# Altium schematic sheet MAIN.SchDoc(MAIN)
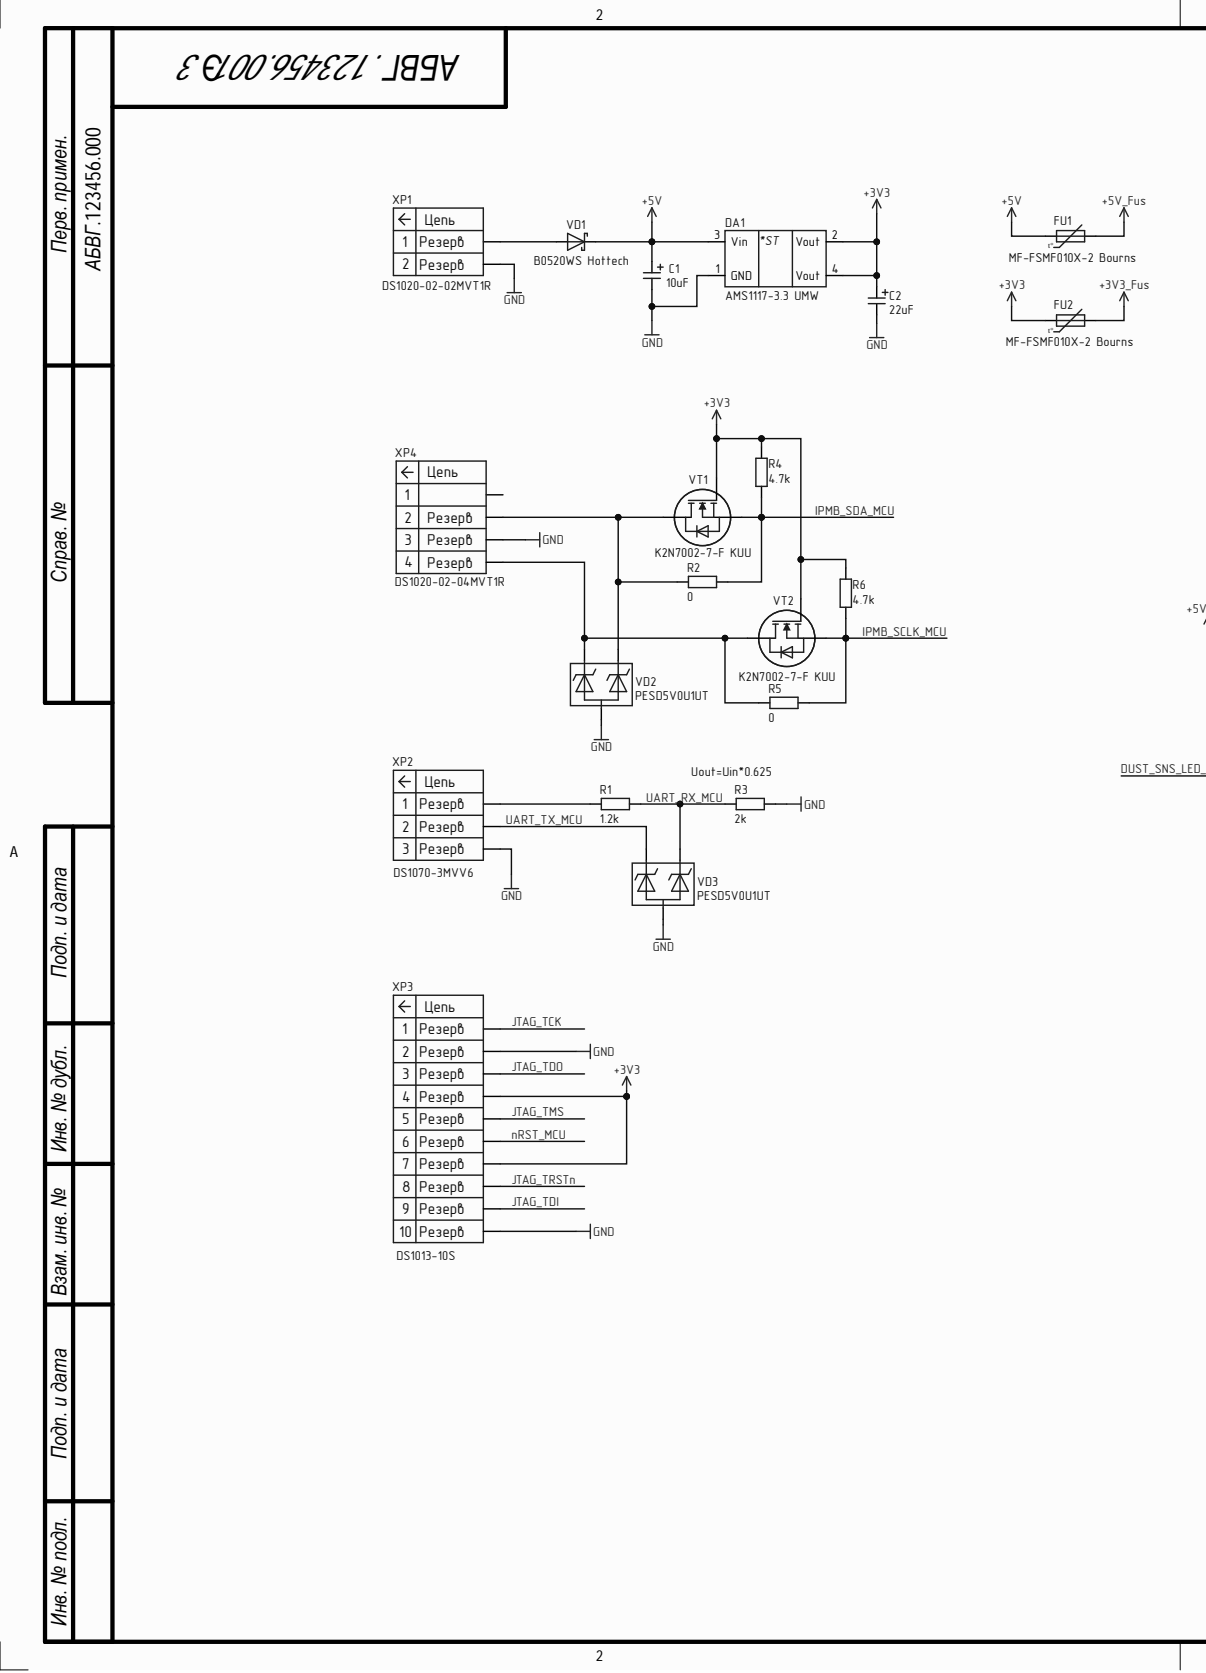
[diagram p0: MAIN.SchDoc, left]
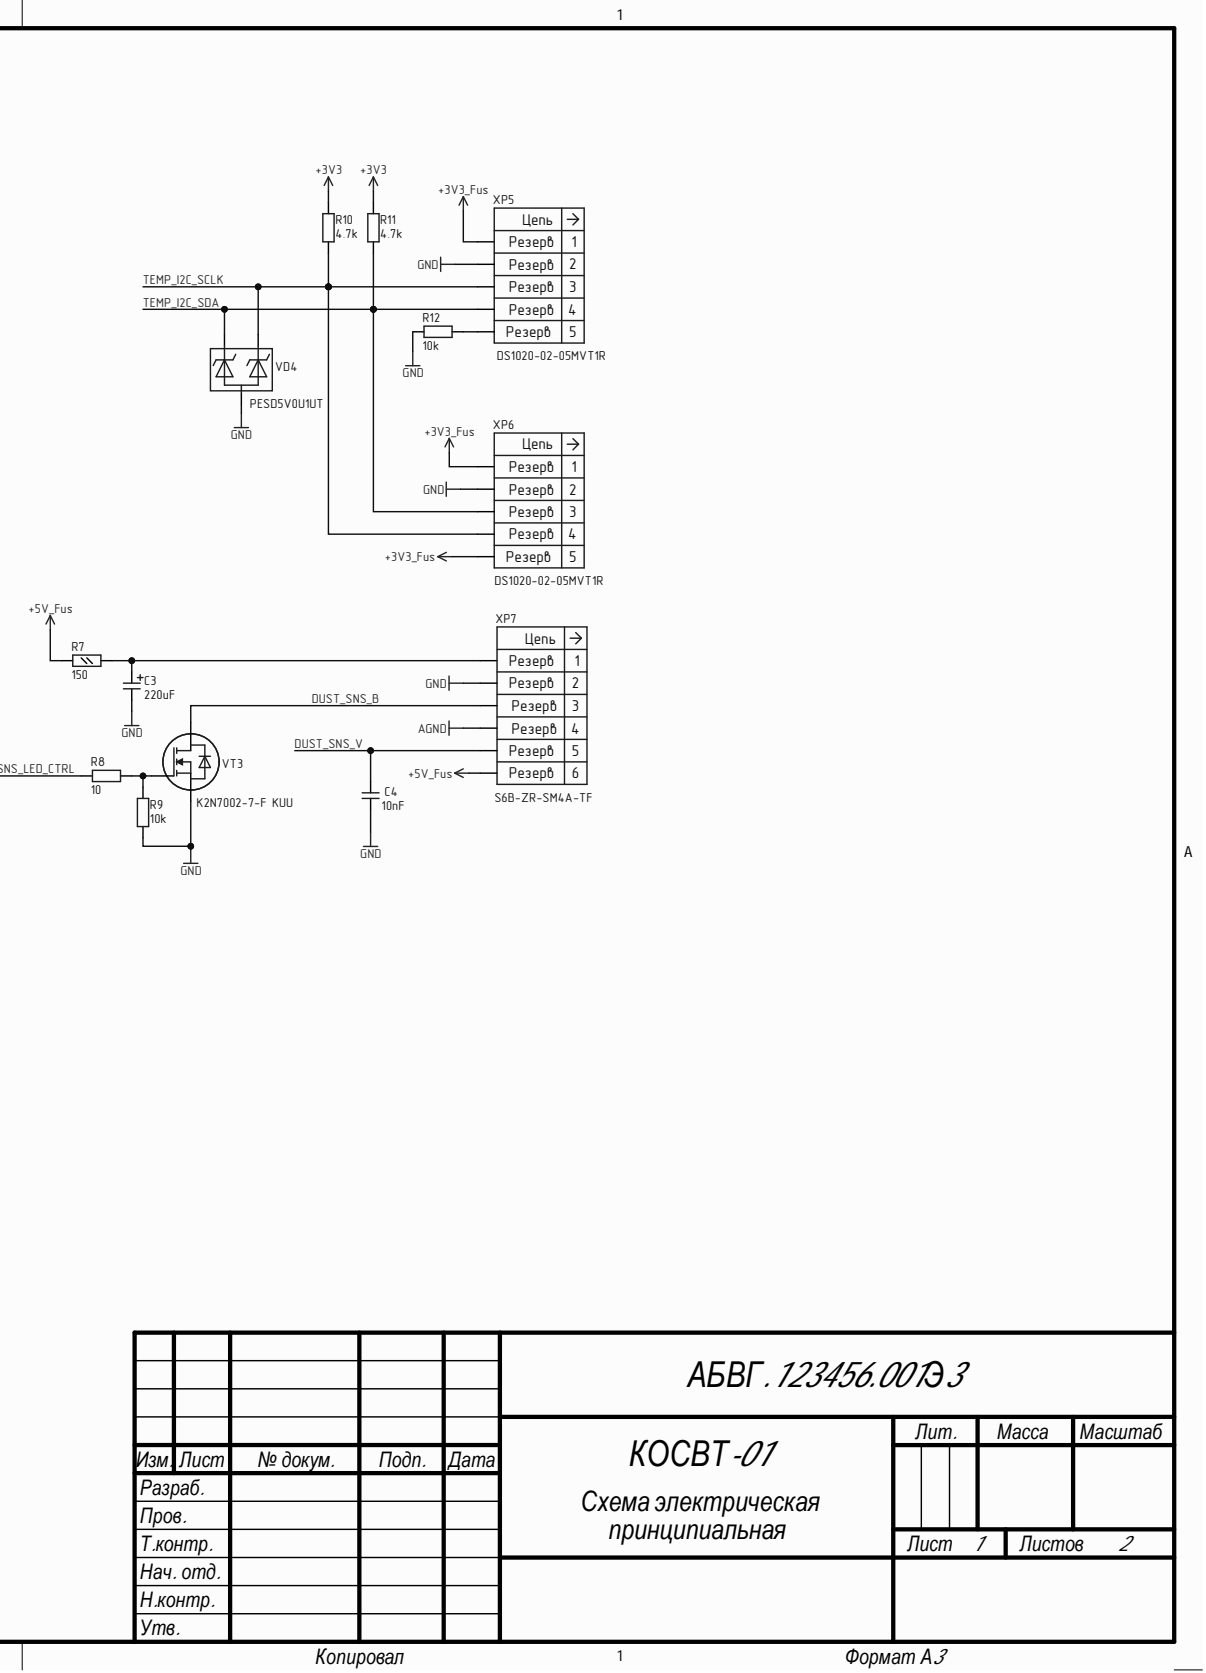
[diagram p1: MAIN.SchDoc, right]

## Parts
C1  10uF
C2  22uF
C3  220uF
C4  10nF
DA1  AMS1117-3.3 UMW  Manufacturer: UMW  Name: AMS1117-3.3
FU1  MF-FSMF010X-2 Bourns  Manufacturer: Bourns  Name: MF-FSMF010X-2
FU2  MF-FSMF010X-2 Bourns  Manufacturer: Bourns  Name: MF-FSMF010X-2
R1  1.2k
R2  0
R3  2k
R4  4.7k
R5  0
R6  4.7k
R7  150
R8  10
R9  10k
R10  4.7k
R11  4.7k
R12  10k
VD1  B0520WS Hottech  Manufacturer: Hottech  Name: B0520WS
VD2  PESD5V0U1UT
VD3  PESD5V0U1UT
VD4  PESD5V0U1UT
VT1  K2N7002-7-F KUU  Manufacturer: KUU  Name: K2N7002-7-F
VT2  K2N7002-7-F KUU  Manufacturer: KUU
VT3  K2N7002-7-F KUU  Name: K2N7002-7-F
XP1  DS1020-02-02MVT1R  Net_1: Резерв
XP2  DS1070-3MVV6  Net_1: Резерв
XP3  DS1013-10S  Net_1: Резерв
XP4  DS1020-02-04MVT1R  Net_1: Резерв
XP5  DS1020-02-05MVT1R  Net_1: Резерв
XP6  DS1020-02-05MVT1R  Net_1: Резерв
XP7  S6B-ZR-SM4A-TF  Net_1: Резерв

## Nets
+3V3: C2.1 DA1.2(Vout) DA1.4(Vout) FU2.1 R4.2 R6.2 R10.2 R11.2 VT1.1 VT2.1 XP3.4(Резерв) XP3.7(Резерв)
+3V3_Fus: FU2.2 XP5.1(Резерв) XP6.1(Резерв) XP6.5(Резерв)
+5V: C1.1 DA1.3(Vin) FU1.1 VD1.2
+5V_Fus: FU1.2 R7.1 XP7.6(Резерв)
AGND: XP7.4(Резерв)
GND: C1.2 C2.2 C3.2 C4.1 DA1.1(GND) R3.1 R9.1 R12.2 VD2.3 VD3.3 VD4.3 VT3.3 XP1.2 XP2.3 XP3.2(Резерв) XP3.10(Резерв) XP4.3(Резерв) XP5.2(Резерв) XP6.2(Резерв) XP7.2(Резерв)
DUST_SNS_B: VT3.2 XP7.3(Резерв)
DUST_SNS_LED_CTRL: R8.1
DUST_SNS_V: C4.2 XP7.5(Резерв)
IPMB_SCLK_MCU: R5.2 R6.1 VT2.3
IPMB_SDA_MCU: R2.2 R4.1 VT1.3
JTAG_TCK: XP3.1(Резерв)
JTAG_TDI: XP3.9(Резерв)
JTAG_TDO: XP3.3(Резерв)
JTAG_TMS: XP3.5(Резерв)
JTAG_TRSTn: XP3.8(Резерв)
nRST_MCU: XP3.6(Резерв)
TEMP_I2C_SCLK: R10.1 VD4.1 XP5.3(Резерв) XP6.4(Резерв)
TEMP_I2C_SDA: R11.1 VD4.2 XP5.4(Резерв) XP6.3(Резерв)
UART_RX_MCU: R1.2 R3.2 VD3.1
UART_TX_MCU: VD3.2 XP2.2
NetC3_1: C3.1 R7.2 XP7.1(Резерв)
NetR1_1: R1.1 XP2.1
NetR2_1: R2.1 VD2.1 VT1.2 XP4.2(Резерв)
NetR5_1: R5.1 VD2.2 VT2.2 XP4.4(Резерв)
NetR8_2: R8.2 R9.2 VT3.1
NetR12_1: R12.1 XP5.5(Резерв)
NetVD1_1: VD1.1 XP1.1

Not connected: XP4 1

## Library data of the parts
C1 = JCK1E100M040054: Конденсатор электролитический 25В-10мкФ ± 20%; Manufacturer: JB Capacitors; Database Table Name: capacitors.csv; Dielectric type: Electrolytic; Group: Конденсаторы полярные; Voltage: 25V; Component Kind: Standard; Country: Китай; Library Name: ComponentsDb.DbLib; Manufacturer Part Number: JCK1E100M040054; Name: JCK1E100M040054; Package: SMD D4x5.4; Tolerance: ± 20%; Type: Конденсатор электролитический; Value: 10uF
C2 = JCK1A220M040054: Конденсатор электролитический 10В-22мкФ ± 20%; Manufacturer: JB Capacitors; Database Table Name: capacitors.csv; Dielectric type: Electrolytic; Group: Конденсаторы полярные; Voltage: 10V; Component Kind: Standard; Country: Китай; Library Name: ComponentsDb.DbLib; Manufacturer Part Number: JCK1A220M040054; Name: JCK1A220M040054; Package: SMD D4x5.4; Tolerance: ± 20%; Type: Конденсатор электролитический; Value: 22uF
C3 = JCK1C221M063077: Конденсатор электролитический 16В-220мкФ ± 20%; Manufacturer: JB Capacitors; Database Table Name: capacitors.csv; Dielectric type: Electrolytic; Group: Конденсаторы полярные; Voltage: 16V; Component Kind: Standard; Country: Китай; Library Name: ComponentsDb.DbLib; Manufacturer Part Number: JCK1C221M063077; Name: JCK1C221M063077; Package: SMD D6.3x7.7; Tolerance: ± 20%; Type: Конденсатор электролитический; Value: 220uF
C4 = GRM21BR72E103KW03L: Конденсатор 0805-X7R-50В-10мкФ ± 10%; Manufacturer: Murata Electronics; Database Table Name: capacitors.csv; Dielectric type: X7R; Group: Конденсаторы; Type: Конденсатор керамический; Voltage: 250V; Component Kind: Standard; Country: Япония; Library Name: ComponentsDb.DbLib; Manufacturer Part Number: GRM21BR72E103KW03L; Name: GRM21BR72E103KW03L; Package: SMD0805; Tolerance: ± 10%; Value: 10nF
DA1 = AMS1117-3.3 UMW: Микросхема AMS1117-3.3; Database Table Name: regulator_ics.csv; Group: Микросхемы; Input Voltage: 4.5 to 12V; Max Temperature: 125°С; Min Temperature: -40°С; Output Current: 1A; Output Voltage: 3.3V; Component Kind: Standard; Country: Китай; HelpURL: https://www.lcsc.com/datasheet/lcsc_datasheet_2412201207_UMW-Youtai-Semiconductor-Co---Ltd--AMS1117-3-3_C347222.pdf; Library Name: ComponentsDb.DbLib; Manufacturer Part Number: AMS1117-3.3 UMW; Package: SOT-223; Type: Линейный регулятор
FU1 FU2 = MF-FSMF010X-2 Bourns: Предохранитель самовосстанавливающийся MF-FSMF010X-2; Database Table Name: fuses.csv; Group: Предохранители; I hold: 0.1A; I trip: 0.3A; V: 15V; Component Kind: Standard; Country: США; HelpURL: chrome-extension://efaidnbmnnnibpcajpcglclefindmkaj/https://static.chipdip.ru/lib/299/DOC012299906.pdf; Library Name: ComponentsDb.DbLib; Manufacturer Part Number: MF-FSMF010X-2 Bourns; Package: SMD0603; Type: Предохранитель самовосстанавливающийся
R1 = RC0603FR-071K2L: Резистор 0603-0,1Вт-1.2кОм ± 1%; Manufacturer: Yageo; Database Table Name: resistors.csv; Group: Резисторы; Power: 0.1W; Voltage: 75V; Component Kind: Standard; Country: Тайвань; Library Name: ComponentsDb.DbLib; Manufacturer Part Number: RC0603FR-071K2L; Name: RC0603FR-071K2L; Package: SMD0603; Tolerance: ± 1%; Type: Резистор; Value: 1.2k
R2 R5 = RC0603FR-070RL: Резистор 0603-0,1Вт-0Ом ± 1%; Manufacturer: Yageo; Database Table Name: resistors.csv; Group: Резисторы; Power: 0.1W; Voltage: 75V; Component Kind: Standard; Country: Тайвань; Library Name: ComponentsDb.DbLib; Manufacturer Part Number: RC0603FR-070RL; Name: RC0603FR-070RL; Package: SMD0603; Tolerance: ± 1%; Type: Резистор; Value: 0
R3 = RC0603FR-072KL: Резистор 0603-0,1Вт-2кОм ± 1%; Manufacturer: Yageo; Database Table Name: resistors.csv; Group: Резисторы; Power: 0.1W; Voltage: 75V; Component Kind: Standard; Country: Тайвань; Library Name: ComponentsDb.DbLib; Manufacturer Part Number: RC0603FR-072KL; Name: RC0603FR-072KL; Package: SMD0603; Tolerance: ± 1%; Type: Резистор; Value: 2k
R4 R6 R10 R11 = RC0603FR-074K7L: Резистор 0603-0,1Вт-4.7кОм ± 1%; Manufacturer: Yageo; Database Table Name: resistors.csv; Group: Резисторы; Power: 0.1W; Voltage: 75V; Component Kind: Standard; Country: Тайвань; HelpURL: https://static.chipdip.ru/lib/175/DOC011175144.pdf; Library Name: ComponentsDb.DbLib; Manufacturer Part Number: RC0603FR-074K7L; Name: RC0603FR-074K7L; Package: SMD0603; Tolerance: ± 1%; Type: Резистор; Value: 4.7k
R7 = RC0805FR-07150RL: Резистор 0805-0,125Вт-150Ом ± 1%; Manufacturer: Yageo; Database Table Name: resistors.csv; Group: Резисторы; Power: 0.125W; Voltage: 150V; Component Kind: Standard; Country: Тайвань; Library Name: ComponentsDb.DbLib; Manufacturer Part Number: RC0805FR-07150RL; Name: RC0805FR-07150RL; Package: SMD0805; Tolerance: ± 1%; Type: Резистор; Value: 150
R8 = RC0603FR-0710RL: Резистор 0603-0,1Вт-10Ом ± 1%; Manufacturer: Yageo; Database Table Name: resistors.csv; Group: Резисторы; Power: 0.1W; Voltage: 75V; Component Kind: Standard; Country: Тайвань; Library Name: ComponentsDb.DbLib; Manufacturer Part Number: RC0603FR-0710RL; Name: RC0603FR-0710RL; Package: SMD0603; Tolerance: ± 1%; Type: Резистор; Value: 10
R9 R12 = RC0603FR-0710KL: Резистор 0603-0,1Вт-10кОм ± 1%; Manufacturer: Yageo; Database Table Name: resistors.csv; Group: Резисторы; Power: 0.1W; Voltage: 75V; Component Kind: Standard; Country: Тайвань; HelpURL: https://static.chipdip.ru/lib/175/DOC011175144.pdf; Library Name: ComponentsDb.DbLib; Manufacturer Part Number: RC0603FR-0710KL; Name: RC0603FR-0710KL; Package: SMD0603; Tolerance: ± 1%; Type: Резистор; Value: 10k
VD1 = B0520WS Hottech: Диод Шоттки B0520WS; Database Table Name: diodes.csv; Group: Диоды; Max If: 500mA; Max Vr: 30V; Component Kind: Standard; Country: Китай; Library Name: ComponentsDb.DbLib; Manufacturer Part Number: B0520WS Hottech; Package: SOD-323; Type: Диод Шоттки
VD2-VD4 = PESD5V0U1UT: Диод ESD PESD5V0U1UT; Manufacturer: UTD Semiconductor; Database Table Name: diodes.csv; Group: Диоды; Type: Сборка ESD диодов; Vrwm: 5V; Component Kind: Standard; Country: Китай; Library Name: ComponentsDb.DbLib; Manufacturer Part Number: PESD5V0U1UT; Name: PESD5V0U1UT; Package: SOT23-132
VT1-VT3 = K2N7002-7-F KUU: Транзистор полевой K2N7002-7-F KUU; Database Table Name: transistors.csv; Group: Транзисторы; Id/Ic: 500mA; Struct: N-MOSFET; Vds/Vce: 60V; Vth: 2.5V; Component Kind: Standard; Country: Гонконг; HelpURL: https://static.chipdip.ru/lib/611/DOC026611395.pdf; Library Name: ComponentsDb.DbLib; Manufacturer Part Number: K2N7002-7-F KUU; Package: SOT-23-GDS; Type: Транзистор полевой
  VT2: Name: K2N7002-7-F
  VT3: Manufacturer: KUU
XP1 = DS1020-02-02MVT1R: Соединитель DS1020-02-02MVT1R; Manufacturer: Connfly; Database Table Name: connectors.csv; Group: Соединители; Max Temperature: 105°С; Min Temperature: -40°С; Nominal Voltage: 250V; Pins number: 2; Rows: 1; Component Kind: Standard; Country: Китай; Library Name: ComponentsDb.DbLib; Manufacturer Part Number: DS1020-02-02MVT1R; Name: DS1020-02-02MVT1R; Net_2: Резерв; Type: Вилка угловая
XP2 = DS1070-3MVV6: Соединитель DS1070-3MVV6; Manufacturer: Connfly; Database Table Name: connectors.csv; Group: Соединители; Max Temperature: 85 °С; Min Temperature: -25°С; Nominal current: 3A; Nominal Voltage: 250V; Pins number: 3; Rows: 1; Component Kind: Standard; Country: Китай; Library Name: ComponentsDb.DbLib; Manufacturer Part Number: DS1070-3MVV6; Name: DS1070-3MVV6; Net_2: Резерв; Net_3: Резерв; Type: Вилка прямая
XP3 = DS1013-10S: Соединитель DS1013-10S; Manufacturer: Connfly; Database Table Name: connectors.csv; Group: Соединители; Max Temperature: 105°С; Min Temperature: -40°С; Nominal current: 1A; Nominal Voltage: 500V; Pins number: 10; Rows: 2; Component Kind: Standard; Country: Китай; Library Name: ComponentsDb.DbLib; Manufacturer Part Number: DS1013-10S; Name: DS1013-10S; Net_2: Резерв; Net_3: Резерв; Net_4: Резерв; Net_5: Резерв; Net_6: Резерв; Net_7: Резерв; Net_8: Резерв; Net_9: Резерв; Net_10: Резерв; Type: Вилка прямая
XP4 = DS1020-02-04MVT1R: Соединитель DS1020-02-04MVT1R; Manufacturer: Connfly; Database Table Name: connectors.csv; Group: Соединители; Max Temperature: 105°С; Min Temperature: -40°С; Nominal Voltage: 250V; Pins number: 4; Rows: 1; Component Kind: Standard; Country: Китай; Library Name: ComponentsDb.DbLib; Manufacturer Part Number: DS1020-02-04MVT1R; Name: DS1020-02-04MVT1R; Net_2: Резерв; Net_3: Резерв; Net_4: Резерв; Type: Вилка угловая
XP5 XP6 = DS1020-02-05MVT1R: Соединитель DS1020-02-05MVT1R; Manufacturer: Connfly; Database Table Name: connectors.csv; Group: Соединители; Max Temperature: 105°С; Min Temperature: -40°С; Nominal Voltage: 250V; Pins number: 5; Rows: 1; Component Kind: Standard; Country: Китай; Library Name: ComponentsDb.DbLib; Manufacturer Part Number: DS1020-02-05MVT1R; Name: DS1020-02-05MVT1R; Net_2: Резерв; Net_3: Резерв; Net_4: Резерв; Net_5: Резерв; Type: Вилка угловая
XP7 = S6B-ZR-SM4A-TF: Соединитель S6B-ZR-SM4A-TF; Manufacturer: JST; Database Table Name: connectors.csv; Group: Соединители; Max Temperature: 85°С; Min Temperature: -25°С; Nominal current: 1A; Nominal Voltage: 50V; Pins number: 6; Rows: 1; Component Kind: Standard; Country: Китай; Library Name: ComponentsDb.DbLib; Manufacturer Part Number: S6B-ZR-SM4A-TF; Name: S6B-ZR-SM4A-TF; Net_2: Резерв; Net_3: Резерв; Net_4: Резерв; Net_5: Резерв; Net_6: Резерв; Type: Вилка угловая
